# Altium schematic sheet 04_mcu_part.SchDoc
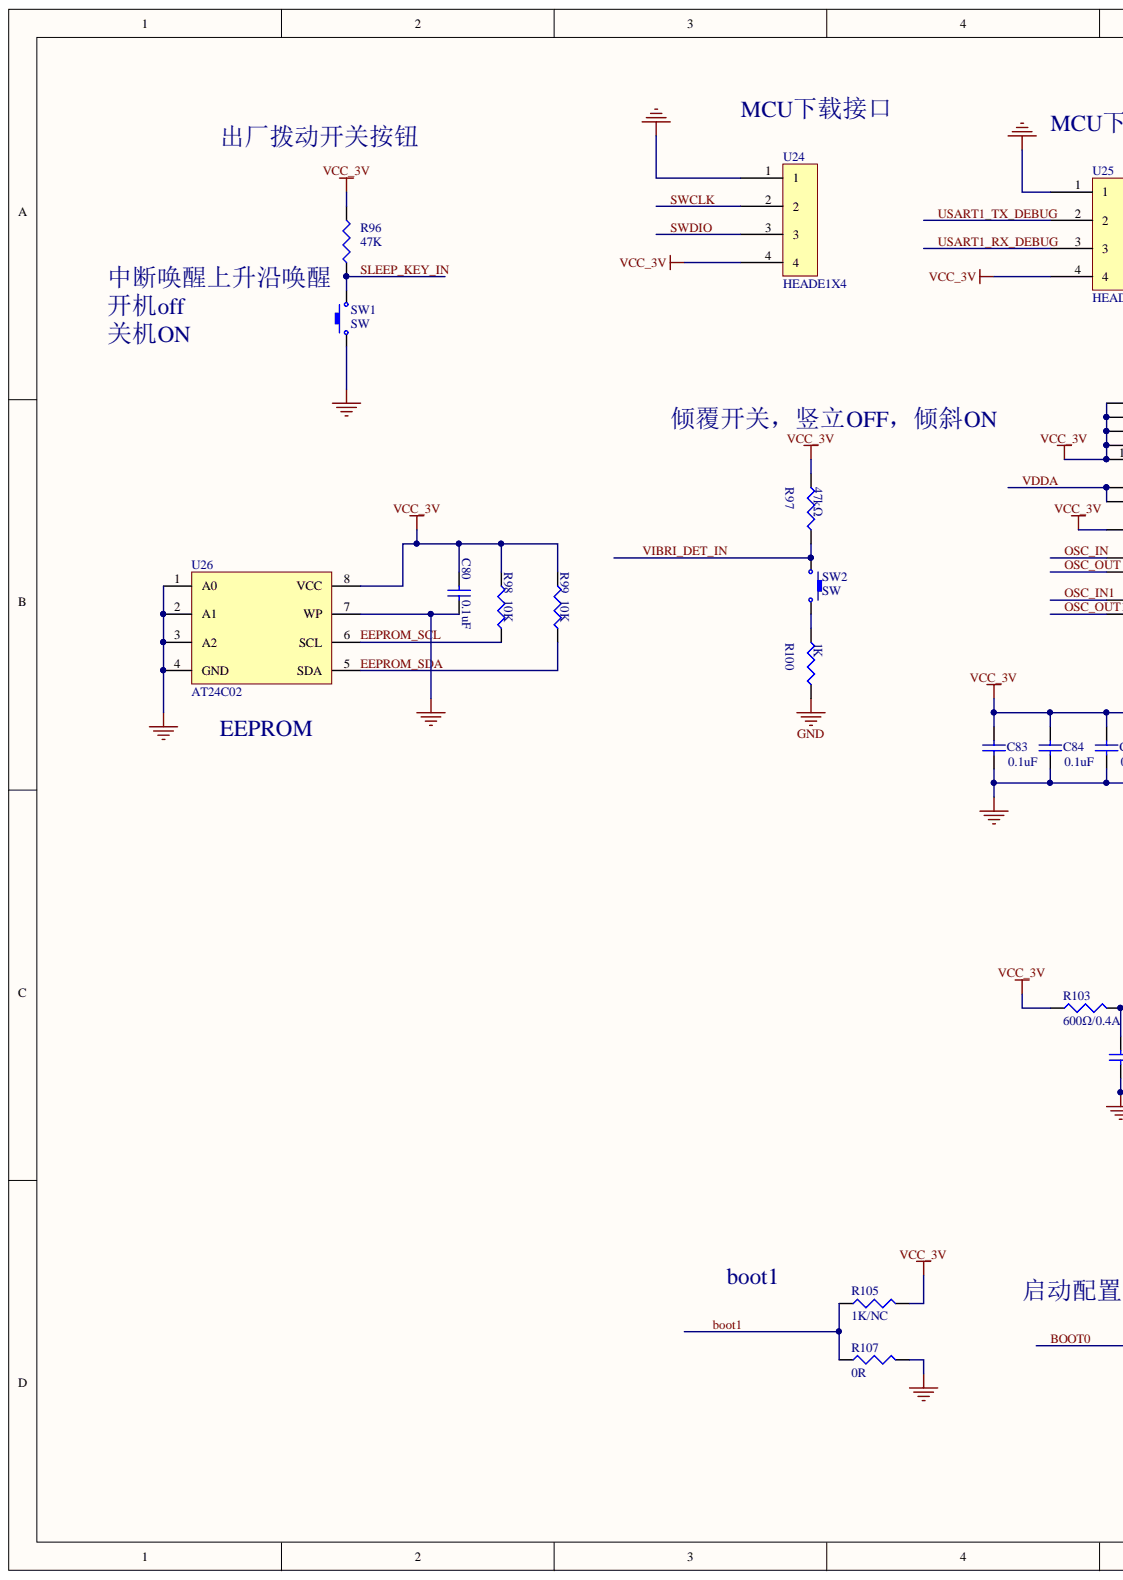
[diagram p0: 04_mcu_part.SchDoc, left]
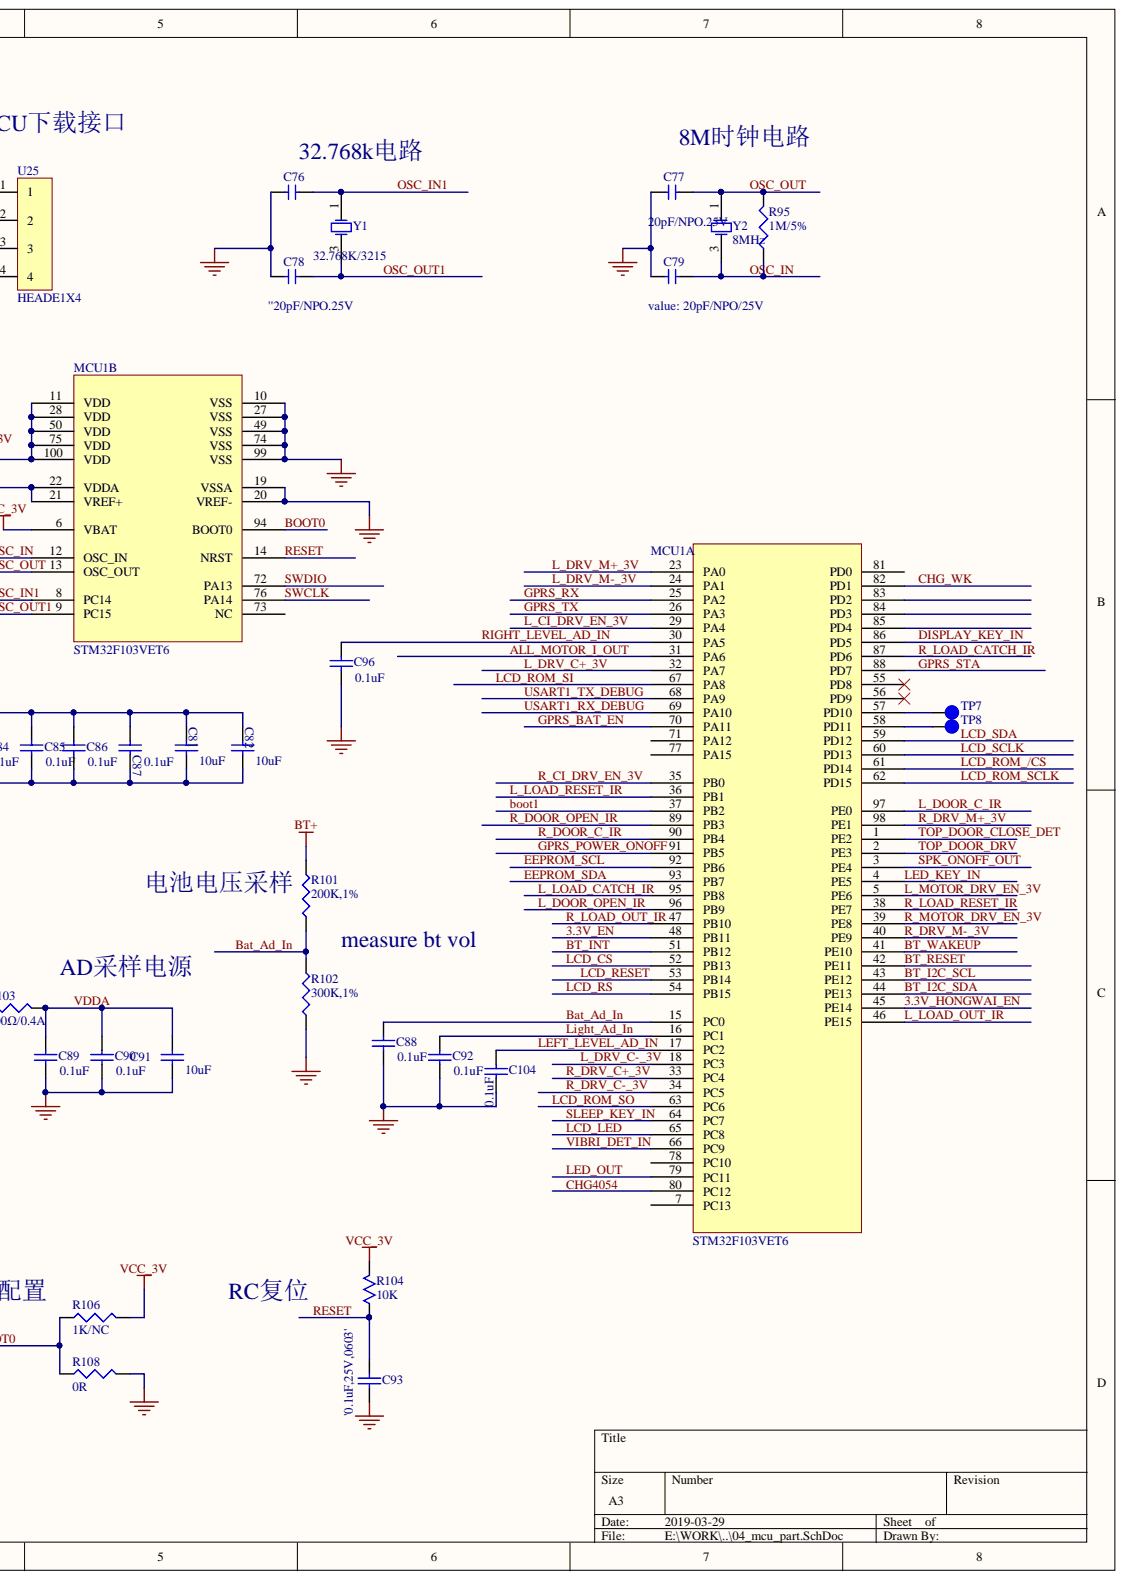
[diagram p1: 04_mcu_part.SchDoc, right]

## Parts
C76  20pF/NPO  [0603_C]  (value not drawn)
C77  20pF/NPO  value 20pF/NPO.25V  [0603_C]
C78  20pF/NPO  value ''20pF/NPO.25V  [0603_C]
C79  20pF/NPO  value 20pF/NPO/25V  [0603_C]
C80  0.1uF  [0603_C]
C81  10uF  [0805_C]
C82  10uF  [0805_C]
C83  0.1uF  [0603_C]
C84  0.1uF  [0603_C]
C85  0.1uF  [0603_C]
C86  0.1uF  [0603_C]
C87  0.1uF  [0603_C]
C88  0.1uF  [0603_C]
C89  0.1uF  [0603_C]
C90  0.1uF  [0603_C]
C91  10uF  [0805_C]
C92  0.1uF  [0603_C]
C93  0.1uF  value '0.1uF,25V,0603'  [0603_C]
C96  0.1uF  [0603_C]
C104  0.1uF  [0603_C]
MCU1  STM32F103VET6  [LQFP100]  (parts A,B)
R95  1M  value 1M/5%  [0603_R]
R96  47K  [0603_R]
R97  47kΩ  [0603_R]
R98  10K  [0603_R]
R99  10K  [0603_R]
R100  1K  [0603_R]
R101  200K,1%  [0603_R]
R102  300K,1%  [0603_R]
R103  value 600Ω/0.4A  [0603_R]  (hidden comment: Res3)
R104  10K  [0603_R]
R105  value 1K/NC  [0603_R]  (hidden comment: NC)
R106  value 1K/NC  [0603_R]  (hidden comment: NC)
R107  value 0R  [0603_R]  (hidden comment: NC)
R108  0R  [0603_R]
SW1  SW  [SW5*5mm]
SW2  SW  [BL-4D-024]
TP7  TP  [TP_1A]
TP8  TP  [TP_1A]
U24  value HEADE1X4  [HEADER1X4_1.25mm]  (hidden comment: IC)
U25  value HEADE1X4  [HEADER1X4_1.25mm]  (hidden comment: IC)
U26  24C02  value AT24C02  [SOP8]
Y1  32.768K/3215  [3215]
Y2  8MHz  [3225]

## Nets
BT+: R101.1
GND: C76.1 C77.1 C78.1 C79.1 C80.1 C81.1 C82.1 C83.1 C84.1 C85.1 C86.1 C87.1 C88.1 C89.1 C90.1 C91.1 C92.1 C93.2 C96.1 C104.1 MCU1.10(VSS) MCU1.19(VSSA) MCU1.20(VREF-) MCU1.27(VSS) MCU1.49(VSS) MCU1.74(VSS) MCU1.99(VSS) R100.1 R102.2 R107.2 R108.2 SW1.1 U24.1 U25.1 U26.1(A0) U26.2(A1) U26.3(A2) U26.4(GND) U26.7(WP)
VCC_3V: C80.2 C81.2 C82.2 C83.2 C84.2 C85.2 C86.2 C87.2 MCU1.6(VBAT) MCU1.11(VDD) MCU1.28(VDD) MCU1.50(VDD) MCU1.75(VDD) MCU1.100(VDD) R96.2 R97.2 R98.2 R99.2 R103.1 R104.2 R105.2 R106.2 U24.4 U25.4 U26.8(VCC)
3.3V_EN: MCU1.48(PB11)
3.3V_HONGWAI_EN: MCU1.45(PE14)
ALL_MOTOR_I_OUT: MCU1.31(PA6)
BAT_AD_IN: C88.2 MCU1.15(PC0) R101.2 R102.1
BOOT0: MCU1.94(BOOT0) R106.1 R108.1
BOOT1: MCU1.37(PB2) R105.1 R107.1
BT_I2C_SCL: MCU1.43(PE12)
BT_I2C_SDA: MCU1.44(PE13)
BT_INT: MCU1.51(PB12)
BT_RESET: MCU1.42(PE11)
BT_WAKEUP: MCU1.41(PE10)
CHG4054: MCU1.80(PC12)
CHG_WK: MCU1.82(PD1)
DISPLAY_KEY_IN: MCU1.86(PD5)
EEPROM_SCL: MCU1.92(PB6) R98.1 U26.6(SCL)
EEPROM_SDA: MCU1.93(PB7) R99.1 U26.5(SDA)
GPRS_BAT_EN: MCU1.70(PA11)
GPRS_POWER_ONOFF: MCU1.91(PB5)
GPRS_RX: MCU1.25(PA2)
GPRS_STA: MCU1.88(PD7)
GPRS_TX: MCU1.26(PA3)
L_CI_DRV_EN_3V: MCU1.29(PA4)
L_DOOR_C_IR: MCU1.97(PE0)
L_DOOR_OPEN_IR: MCU1.96(PB9)
L_DRV_C+_3V: MCU1.32(PA7)
L_DRV_C-_3V: MCU1.18(PC3)
L_DRV_M+_3V: MCU1.23(PA0)
L_DRV_M-_3V: MCU1.24(PA1)
L_LOAD_CATCH_IR: MCU1.95(PB8)
L_LOAD_OUT_IR: MCU1.46(PE15)
L_LOAD_RESET_IR: MCU1.36(PB1)
L_MOTOR_DRV_EN_3V: MCU1.5(PE6)
LCD_CS: MCU1.52(PB13)
LCD_LED: MCU1.65(PC8)
LCD_RESET: MCU1.53(PB14)
LCD_ROM_/CS: MCU1.61(PD14)
LCD_ROM_SCLK: MCU1.62(PD15)
LCD_ROM_SI: MCU1.67(PA8)
LCD_ROM_SO: MCU1.63(PC6)
LCD_RS: MCU1.54(PB15)
LCD_SCLK: MCU1.60(PD13)
LCD_SDA: MCU1.59(PD12)
LED_KEY_IN: MCU1.4(PE5)
LED_OUT: MCU1.79(PC11)
LEFT_LEVEL_AD_IN: C104.2 MCU1.17(PC2)
LIGHT_AD_IN: C92.2 MCU1.16(PC1)
OSC_IN: C79.2 MCU1.12(OSC_IN) R95.1 Y2.3
OSC_IN1: C76.2 MCU1.8(PC14) Y1.1
OSC_OUT: C77.2 MCU1.13(OSC_OUT) R95.2 Y2.1
OSC_OUT1: C78.2 MCU1.9(PC15) Y1.3
R_CI_DRV_EN_3V: MCU1.35(PB0)
R_DOOR_C_IR: MCU1.90(PB4)
R_DOOR_OPEN_IR: MCU1.89(PB3)
R_DRV_C+_3V: MCU1.33(PC4)
R_DRV_C-_3V: MCU1.34(PC5)
R_DRV_M+_3V: MCU1.98(PE1)
R_DRV_M-_3V: MCU1.40(PE9)
R_LOAD_CATCH_IR: MCU1.87(PD6)
R_LOAD_OUT_IR: MCU1.47(PB10)
R_LOAD_RESET_IR: MCU1.38(PE7)
R_MOTOR_DRV_EN_3V: MCU1.39(PE8)
RESET: C93.1 MCU1.14(NRST) R104.1
RIGHT_LEVEL_AD_IN: C96.2 MCU1.30(PA5)
SLEEP_KEY_IN: MCU1.64(PC7) R96.1 SW1.2
SPK_ONOFF_OUT: MCU1.3(PE4)
SWCLK: MCU1.76(PA14) U24.2
SWDIO: MCU1.72(PA13) U24.3
TOP_DOOR_CLOSE_DET: MCU1.1(PE2)
TOP_DOOR_DRV: MCU1.2(PE3)
USART1_RX_DEBUG: MCU1.69(PA10) U25.3
USART1_TX_DEBUG: MCU1.68(PA9) U25.2
VDDA: C89.2 C90.2 C91.2 MCU1.21(VREF+) MCU1.22(VDDA) R103.2
VIBRI_DET_IN: MCU1.66(PC9) R97.1 SW2.1
NetMCU1_57: MCU1.57(PD10) TP7.1
NetMCU1_58: MCU1.58(PD11) TP8.1
NetR100_2: R100.2 SW2.2

Not connected: MCU1 7(PC13) 55(PD8) 56(PD9) 71(PA12) 73(NC) 77(PA15) 78(PC10) 81(PD0) 83(PD2) 84(PD3) 85(PD4)

## Library data of the parts
C76 = Cap: Value: ''20pF/NPO.25V; Component Kind: Standard; Library Name: Celestial.SchLib; Type: Cap
C77 = Cap: value: 20pF/NPO.25V; Component Kind: Standard; Library Name: Celestial.SchLib; Type: Cap
C78 C79 = Cap: Component Kind: Standard; Library Name: Celestial.SchLib; Type: Cap
C80 C83-C90 C92 C96 C104 = Cap: Capacitor; Component Kind: Standard; LatestRevisionDate: 17-Jul-2002; LatestRevisionNote: Re-released for DXP Platform.; Library Name: Miscellaneous Devices.IntLib; PackageReference: RAD-0.3; Published: 8-Jun-2000; Value: 0.1uF; Publisher: Altium Limited
C81 C82 C91 = Cap Semi: Capacitor (Semiconductor SIM Model); Type: Cap; Component Kind: Standard; LatestRevisionDate: 17-Jul-2002; LatestRevisionNote: Re-released for DXP Platform.; PackageReference: C1206; Published: 8-Jun-2000; Publisher: Altium Limited
C93 = CAP: GRM39 X7R102K50PT; Component Kind: Standard; Library Name: Miscellaneous Devices.IntLib; Published: 8-Jun-2000; REF: GRM39 X7R102K50PT; Revision: July-2002: Re-released for DXP Platform.; Signal Integrity: Cap; Simulation: CAP; Publisher: Altium Limited
R95 R103 R105-R108 = Res3: Resistor; Component Kind: Standard; LatestRevisionDate: 17-Jul-2002; LatestRevisionNote: Re-released for DXP Platform.; Published: 8-Jun-2000; Publisher: Altium Limited
  R108: Value: 0R
R96-R102 = Res: Component Kind: Standard; Type: Res
  R96-R100: Library Name: Celestial.SchLib
  R101 R102: Library Name: Celestial SCH.LIB
R104 = RES: Component Kind: Standard; Library Name: YT1_SCH_99SE.SCHLIB
SW1 SW2 = SW_PB: Component Kind: Standard; Value: SW; Library Name: Jackson SCH.LIB
U24 U25 = HEADE1X4: Component Kind: Standard; Library Name: Jackson SCH.LIB
U26 = AT24C01: Component Kind: Standard; Library Name: Jackson SCH.LIB
Y1 Y2 = 3225: Crystal Oscillator; Component Kind: Standard; LatestRevisionDate: 17-Jul-2002; LatestRevisionNote: Re-released for DXP Platform.; Published: 8-Jun-2000; Library Name: XLLD_SCH.SCHLIB; Publisher: Altium Limited
MCU1 = STM32F103VET6: Component Kind: Standard; Library Name: Jackson SCH.LIB
TP7 TP8 = TP: Component Kind: Standard; Library Name: Jackson SCH.LIB
